# Altium schematic sheet 09- I2C, LEDs And Push Button.SchDoc
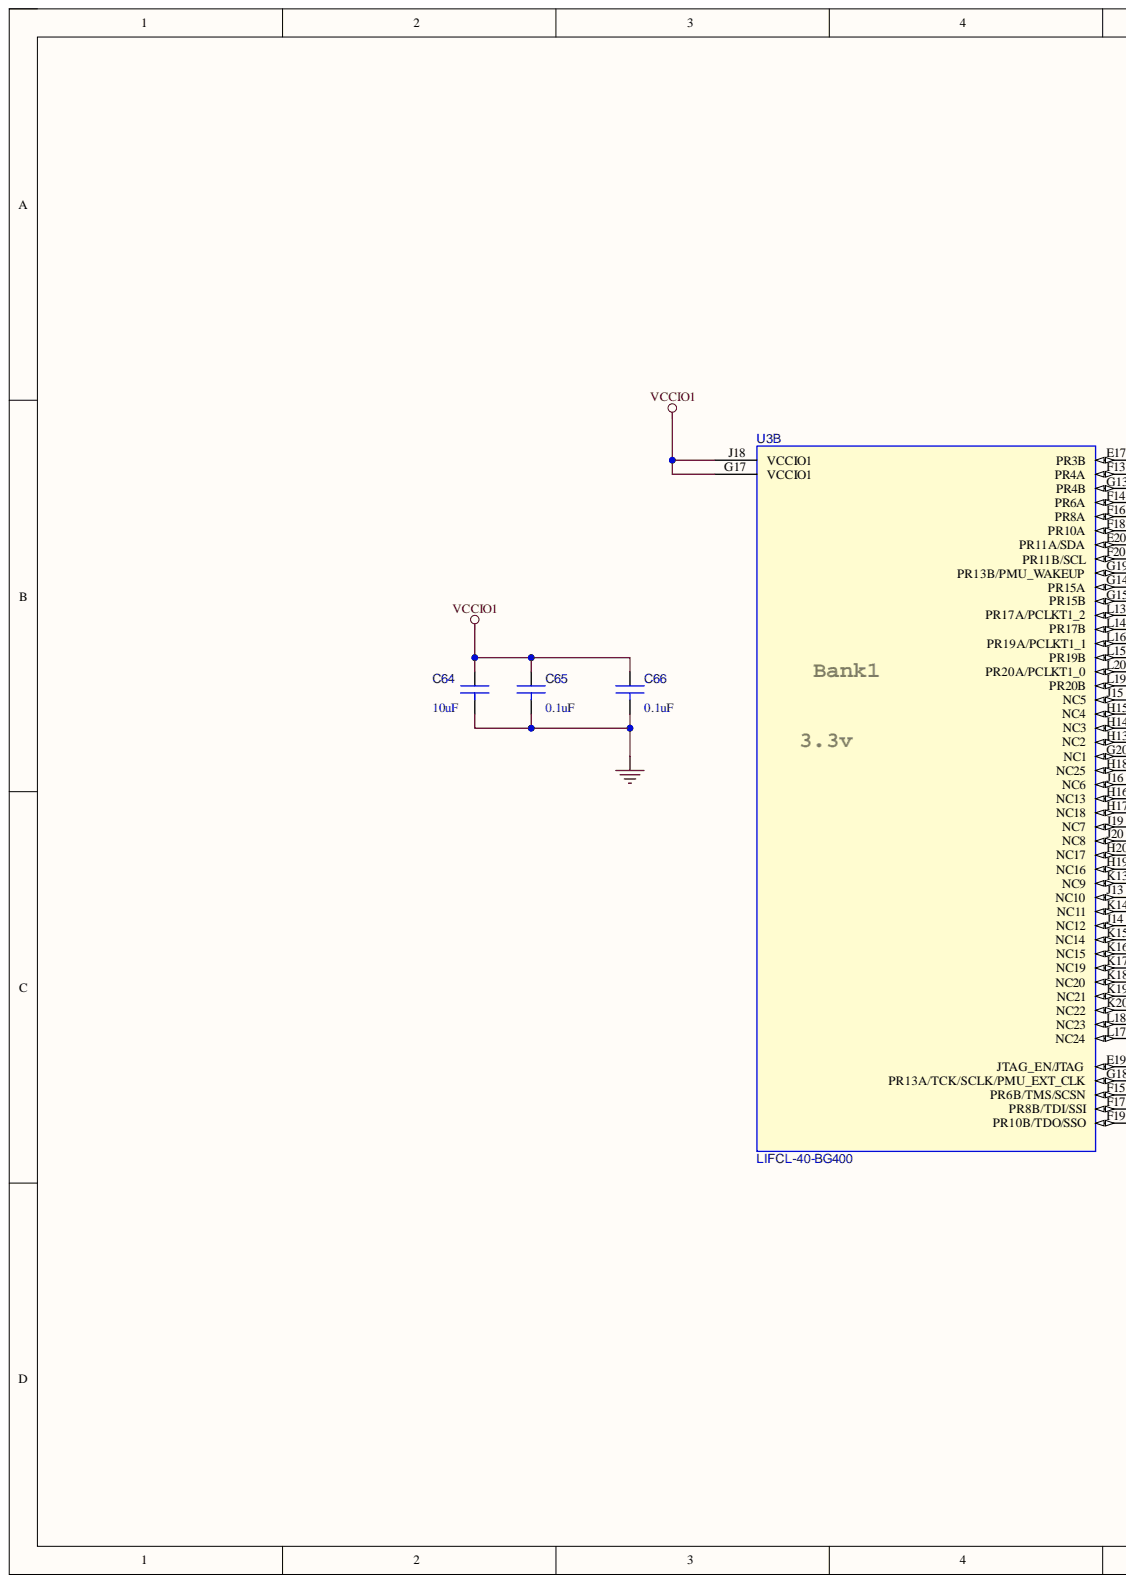
[diagram p0: 09- I2C, LEDs And Push Button.SchDoc, left]
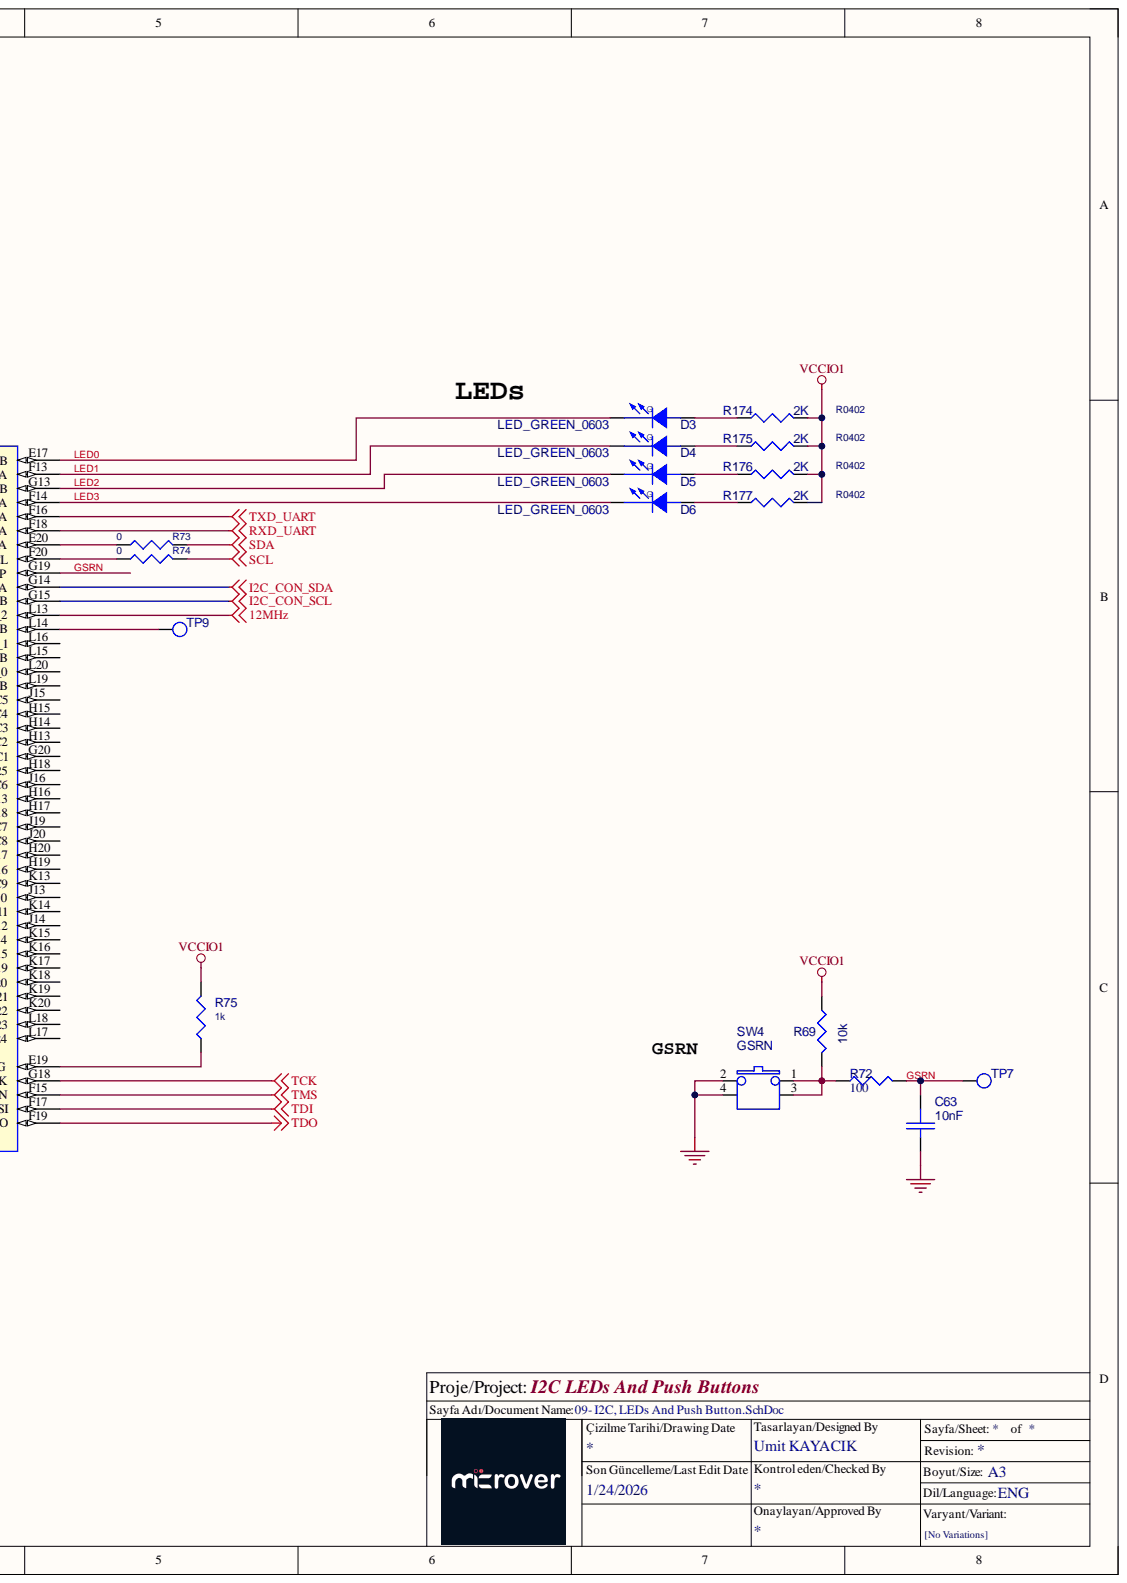
[diagram p1: 09- I2C, LEDs And Push Button.SchDoc, right]

## Parts
C63  10nF  Part Reference: C63  [RLP-130-A]
C64  10uF  Part Reference: C64  [C0603]
C65  0.1uF  Part Reference: C65  [C0201]
C66  0.1uF  Part Reference: C66  [C0201]
D3  LED_GREEN_0603  Part Reference: D3  [APT1608]
D4  LED_GREEN_0603  Part Reference: D4  [APT1608]
D5  LED_GREEN_0603  Part Reference: D5  [APT1608]
D6  LED_GREEN_0603  Part Reference: D6  [APT1608]
R69  10k  Part Reference: R69  [R0402]
R72  100  Part Reference: R72  [R0402]
R73  0  Part Reference: R73  [R0402]
R74  0  Part Reference: R74  [R0402]
R75  1k  Part Reference: R75  [R0402]
R174  2K  Part Reference: R174  [R0402]
R175  2K  Part Reference: R175  [R0402]
R176  2K  Part Reference: R176  [R0402]
R177  2K  Part Reference: R177  [R0402]
SW4  GSRN  Part Reference: SW4  [4psmd_switch]
TP7  Part Reference: TP7  [TP50]  (hidden comment: TestPoint)
TP9  Part Reference: TP9  [TP50]  (hidden comment: TestPoint)
U3  LIFCL-40-BG400  [LIFCL-40-BG400]  (part B)

## Nets
12MHz: U3.L13(PR17A/PCLKT1_2)
GND: C63.2 C64.2 C65.2 C66.2 SW4.2 SW4.4
I2C_CON_SCL: U3.G15(PR15B)
I2C_CON_SDA: U3.G14(PR15A)
RXD_UART: U3.F18(PR10A)
SCL: R74.1
SDA: R73.1
TCK: U3.G18(PR13A/TCK/SCLK/PMU_EXT_CLK)
TDI: U3.F17(PR8B/TDI/SSI)
TDO: U3.F19(PR10B/TDO/SSO)
TMS: U3.F15(PR6B/TMS/SCSN)
TXD_UART: U3.F16(PR8A)
VCCIO1: C64.1 C65.1 C66.1 R69.1 R75.2 R174.2 R175.2 R176.2 R177.2 U3.G17(VCCIO1) U3.J18(VCCIO1)
GSRN: C63.1 R72.2 TP7.1 U3.G19(PR13B/PMU_WAKEUP)
LED0: D3.1 U3.E17(PR3B)
LED1: D4.1 U3.F13(PR4A)
LED2: D5.1 U3.G13(PR4B)
LED3: D6.1 U3.F14(PR6A)
NetD3_2: D3.2 R174.1
NetD4_2: D4.2 R175.1
NetD5_2: D5.2 R176.1
NetD6_2: D6.2 R177.1
NetR69_2: R69.2 R72.1 SW4.1 SW4.3
NetR73_2: R73.2 U3.E20(PR11A/SDA)
NetR74_2: R74.2 U3.F20(PR11B/SCL)
NetR75_1: R75.1 U3.E19(JTAG_EN/JTAG)
NetTP9_1: TP9.1 U3.L14(PR17B)

Not connected: U3 G20(NC1) H13(NC2) H14(NC3) H15(NC4) H16(NC13) H17(NC18) H18(NC25) H19(NC16) H20(NC17) J13(NC10) J14(NC12) J15(NC5) J16(NC6) J19(NC7) J20(NC8) K13(NC9) K14(NC11) K15(NC14) K16(NC15) K17(NC19) K18(NC20) K19(NC21) K20(NC22) L15(PR19B) L16(PR19A/PCLKT1_1) L17(NC24) L18(NC23) L19(PR20B) L20(PR20A/PCLKT1_0)

## Library data of the parts
C63 = CAP_1: Assy: -; Component Kind: Standard; PCB Footprint: RLP-130-A; Value: 10nF
C64 = 10uF_0603: CAP CECAP CER 10UF 10V X5R 20% 0603; Manufacturer: Taiyo Yuden; Manufacturer Part Number: LMK107BJ106MALTD; Class: Discrete; Assy: -; Component Kind: Standard; Digikey P/N: 587-2562-1-ND; PCB Footprint: C0603; Value: 10uF
C65 C66 = 0u1_0402: CAP CER 0.1UF 25V 10% X5R 0201; Manufacturer: Murata; Manufacturer Part Number: GRM033R61E104KE14J; Class: Discrete; Assy: -; Component Kind: Standard; Digikey P/N: 399-3521-2-ND; PCB Footprint: C0201; Value: 0.1uF
D3-D6 = LED-SMT1206_GREEN_1: Assy: -; Component Kind: Standard; PCB Footprint: APT1608; Value: LED_GREEN_0603
R69 = RES_0: RES SMD 10K OHM 1% 1/16W 0402; Manufacturer: Yageo; Manufacturer Part Number: RC0402FR-0710KL; Assy: -; Component Kind: Standard; MFG: Yageo; MFG P/N: RC0402FR-0710KL; PCB Footprint: R0402; Value: 10k
R72 = 0R_0603: RES SMD 100 OHM 1% 1/16W 0402; Manufacturer: Yageo; Manufacturer Part Number: RC0402FR-07100RL; Class: Discrete; Assy: -; Component Kind: Standard; Digikey P/N: 311-0.0GRTR-ND; MFG: Yageo; PCB Footprint: R0402; Value: 100
R73 R74 = R_Dup3: RES SMD 33 OHM 1% 1/10W 0402; Manufacturer: Panasonic; Manufacturer Part Number: ERJ-2RKF33R0X; Assy: -; Component Kind: Standard; PCB Footprint: R0402; Value: 0
R75 = RES_100K_1W16_0402_5P: RES 100K OHM 1/10W 1% 0402 SMD; Manufacturer: Panasonic; Manufacturer Part Number: ERJ-2RKF1003X; DIST: FLAT; MAX_TEMP: RTMAX; TC1: 0; Total Area(sq-mm): 0.5; Unit Price (USD): 0.02; Wattage: 1/16W; Assy: -; Component Kind: Standard; Length(mm): 1; MFG: Panasonic; MFG P/N: ERJ-2RKF1003X; PART_NUMBER: ERJ-2RKF1003X; PCB Footprint: R0402; TC2: 0; Value: 1k; Width(mm): 0.5
R174-R177 = RES_0: RES SMD 2K OHM 5% 1/10W 0402; Manufacturer: Panasonic; Manufacturer Part Number: ERJ-2GEJ202X; Assy: -; Component Kind: Standard; MFG: Panasonic; MFG P/N: ERJ-2GEJ202X; PCB Footprint: R0402; Value: 2K
SW4 = SW PUSHBUTTON-SPST/SM: SWITCH TACTILE SPST-NO 0.05A 12V; Man. Part #: 434153017835; Manufacturer: Wurth; Assy: -; Component Kind: Standard; Manufacturer Part Number: 434153017835; MFG: Wurth; MFG P/N: 434153017835; PCB Footprint: 4psmd_switch; Value: GSRN
TP7 TP9 = T POINT R: Assy: -; Component Kind: Standard; PCB Footprint: TP50; Value: TestPoint
U3 = LIFCL-40-BG400: Part Reference: U3; Graphic: LIFCL-40-BG400A.Normal; Implementation Type: <none>; Location X-Coordinate: 0; Name: I17266603; Power Pins Visible: False; Primitive: DEFAULT; Source Library: C:\USERS\SLIU1\DOCUMENTS\PCB_DESIGN\JEDI_EVAL_BOARD\SCHEMATIC\LIFCL40.OLB; SPLIT_INST: TRUE; SWAP_INFO: (S1+S2+S3+S4+S5+S6+S7+S8+S9+S10+S11+S12+S13+S14+S15); Assy: -; Color: Default; Component Kind: Standard; Location Y-Coordinate: 0; PCB Footprint: LIFCL-40-BG400; Source Package: LIFCL-40-BG400; Source Part: LIFCL-40-BG400A.Normal; Value: LIFCL-40-BG400
